# Altium schematic sheet Logic.SchDoc(Logic)
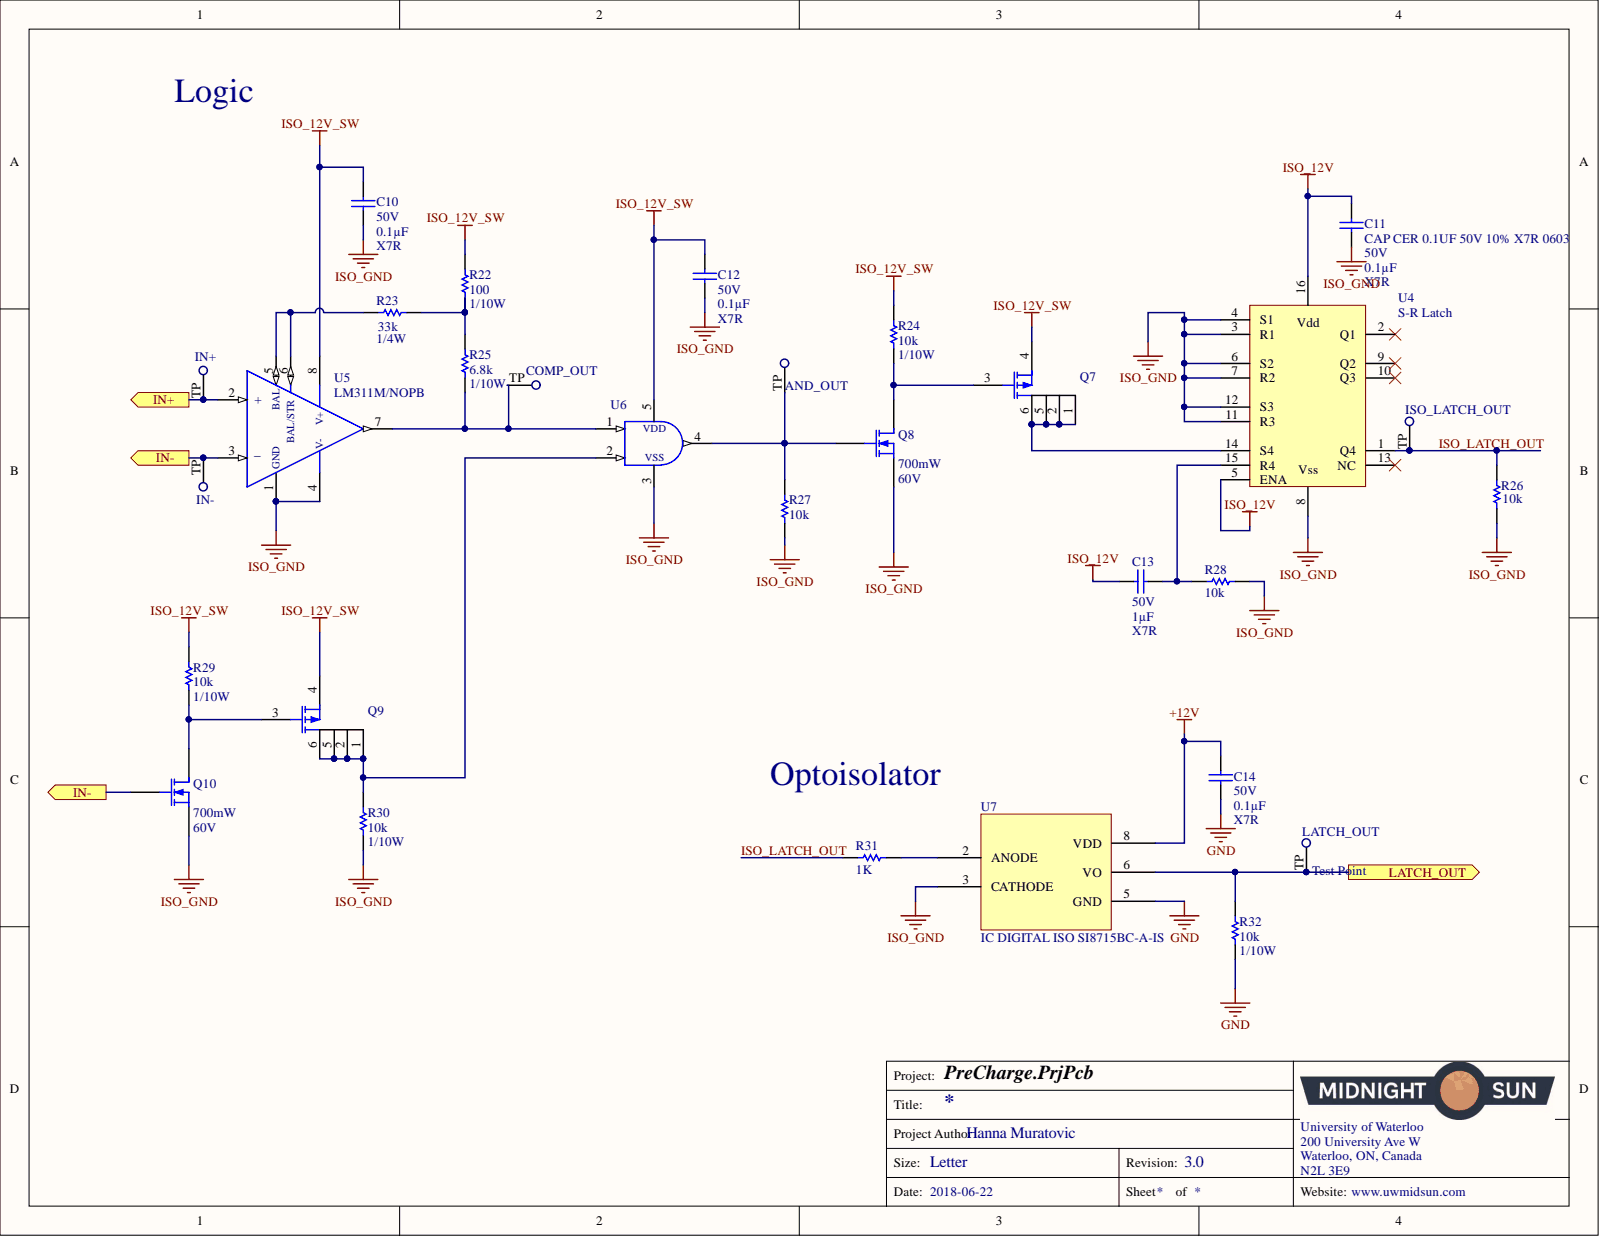
[diagram p0: Logic.SchDoc]

## Parts
AND_OUT  Test Point  [Test Point]  (no BOM)
C10  CAP CER 0.1UF 50V 10% X7R 0603  Voltage: 50V  Temperature Coefficient: X7R  Capacitance: 0.1µF  [CAP, 0603]
C11  CAP CER 0.1UF 50V 10% X7R 0603  Voltage: 50V  [CAP, 0603]
C12  CAP CER 0.1UF 50V 10% X7R 0603  Voltage: 50V  Temperature Coefficient: X7R  Capacitance: 0.1µF  [CAP, 0603]
C13  Voltage: 50V  Capacitance: 1µF  [CAP, 0603]  (hidden comment: CAP CER 1UF 50V 10% X7R 0603)
C14  CAP CER 0.1UF 50V 10% X7R 0603  Voltage: 50V  Temperature Coefficient: X7R  Capacitance: 0.1µF  [CAP, 0603]
COMP_OUT  Test Point  [Test Point]  (no BOM)
IN+  Test Point  [Test Point]  (no BOM)
IN-  Test Point  [Test Point]  (no BOM)
ISO_LATCH_OUT  Test Point  [Test Point]  (no BOM)
LATCH_OUT  Test Point  [Test Point]  (no BOM)
Q7  MOSFET P-CH 30V 4A 1.6W SOT-23-6  [P-MOSFET]  (value not drawn)
Q8  Max Power Dissipation: 700mW  Drain to Source Voltage (Vdss): 60V  [SOT23]  (hidden comment: MOSFET N-CH 60V 310MA SOT23)
Q9  MOSFET P-CH 30V 4A 1.6W SOT-23-6  [P-MOSFET]  (value not drawn)
Q10  Max Power Dissipation: 700mW  Drain to Source Voltage (Vdss): 60V  [SOT23]  (hidden comment: MOSFET N-CH 60V 310MA SOT23)
R22  Power: 1/10W  [RES, 0603]  (hidden comment: RES 100 OHM 1% 1/10W 0603)
R23  Resistance: 33k  [RES, 0603]  (hidden comment: RES 33K OHM 1% 1/4W 0603)
R24  Resistance: 10k  Power: 1/10W  [RES, 0603]  (hidden comment: RES 10K OHM 1% 1/10W 0603)
R25  Resistance: 6.8k  Power: 1/10W  [RES, 0603]  (hidden comment: RES 6.8k OHM 1% 1/10W 0603)
R26  Resistance: 10k  [RES, 0603]  (hidden comment: RES 10K OHM 1% 1/10W 0603)
R27  Resistance: 10k  [RES, 0603]  (hidden comment: RES 10K OHM 1% 1/10W 0603)
R28  Resistance: 10k  [RES, 0603]  (hidden comment: RES 10K OHM 1% 1/10W 0603)
R29  Resistance: 10k  Power: 1/10W  [RES, 0603]  (hidden comment: RES 10K OHM 1% 1/10W 0603)
R30  Resistance: 10k  Power: 1/10W  [RES, 0603]  (hidden comment: RES 10K OHM 1% 1/10W 0603)
R31  1K  [RES, 0603]
R32  Resistance: 10k  Power: 1/10W  [RES, 0603]  (hidden comment: RES 10K OHM 1% 1/10W 0603)
U4  Logic Type: S-R Latch  [IC, SR Latch, CD4043BDR]  (hidden comment: IC SR LATCH 4CH 16SOIC)
U5  Manufacturer Part Number 1: LM311M/NOPB  [SOIC8]  (hidden comment: IC COMPARATOR 8-SOIC)
U6  IC AND GATE 1CH 5-SSOP  [IC, 2-Input AND Gate]  (value not drawn)
U7  IC DIGITAL ISO SI8715BC-A-IS  Manufacturer Part Number: SI8715BC-A-IS  [SOIC8]

## Ports
IN+, IN-, LATCH_OUT

## Nets
+12V: C14.1 U7.8(VDD)
GND: C14.2 R32.2 U7.5(GND)
ISO_12V: C11.2 C13.2 U4.5(ENA) U4.16
ISO_12V_SW: C10.2 C12.2 Q7.4 Q9.4 R22.1 R24.1 R29.1 U5.8 U6.5
ISO_GND: C10.1 C11.1 C12.1 Q8.2 Q10.2 R26.1 R27.1 R28.1 R30.2 U4.3(R1) U4.4(S1) U4.6(S2) U4.7(R2) U4.8 U4.11(R3) U4.12(S3) U5.1(GND) U5.4 U6.3 U7.3(CATHODE)
ISO_LATCH_OUT: ISO_LATCH_OUT.TP R26.2 R31.1 U4.1(Q4)
LATCH_OUT: LATCH_OUT.TP R32.1 U7.6(VO) ; port LATCH_OUT
NetAND_OUT_TP: AND_OUT.TP Q8.3 R27.2 U6.4
NetC13_1: C13.1 R28.2 U4.15(R4)
NetCOMP_OUT_TP: COMP_OUT.TP R25.2 U5.7 U6.1(VDD)
NetIN+_TP: IN+.TP U5.2(+)
NetIN-_TP: IN-.TP Q10.3 U5.3(_)
NetQ7_1: Q7.1 Q7.2 Q7.5 Q7.6 U4.14(S4)
NetQ7_3: Q7.3 Q8.1 R24.2
NetQ9_1: Q9.1 Q9.2 Q9.5 Q9.6 R30.1 U6.2(VSS)
NetQ9_3: Q9.3 Q10.1 R29.2
NetR22_2: R22.2 R23.2 R25.1
NetR23_1: R23.1 U5.5(BAL) U5.6(BAL/STR)
NetR31_2: R31.2 U7.2(ANODE)

Not connected: U4 2(Q1) 9(Q2) 10(Q3) 13(NC)

## Library data of the parts
C10 C12 C14 = CAP CER 0.1UF 50V 10% X7R 0603: 0.10µF ±10% 50V Ceramic Capacitor X7R 0603 (1608 Metric); Manufacturer Part Number 1: 06035C104KAT2A; Manufacturer 1: AVX Corporation; Tolerance: ±10%; Thickness (Max): 0.035" (0.90mm); Supplier Part Number 1: 478-5052-1-ND; Supplier 1: Digi-Key; Size / Dimension: 0.063" L x 0.032" W (1.60mm x 0.81mm); Ratings: -; Part Status: Active; Packaging: Cut Tape (CT); Package / Case: 0603 (1608 Metric); Operating Temperature: -55°C ~ 125°C; Mounting Type: Surface Mount, MLCC; Lead Style: -; Lead Spacing: -; Height - Seated (Max): -; ComponentLink1URL: http://datasheets.avx.com/X7RDielectric.pdf; ComponentLink1Description: http://datasheets.avx.com/X7RDielectric.pdf; Component Kind: Standard; Library Name: Schematic Diagrams.SchLib
C11 = CAP CER 0.1UF 50V 10% X7R 0603: 0.10µF ±10% 50V Ceramic Capacitor X7R 0603 (1608 Metric); Manufacturer Part Number 1: 06035C104KAT2A; Manufacturer 1: AVX Corporation; Capacitance: 0.1µF; Tolerance: ±10%; Thickness (Max): 0.035" (0.90mm); Temperature Coefficient: X7R; Supplier Part Number 1: 478-5052-1-ND; Supplier 1: Digi-Key; Size / Dimension: 0.063" L x 0.032" W (1.60mm x 0.81mm); Ratings: -; Part Status: Active; Packaging: Cut Tape (CT); Package / Case: 0603 (1608 Metric); Operating Temperature: -55°C ~ 125°C; Mounting Type: Surface Mount, MLCC; Lead Style: -; Lead Spacing: -; Height - Seated (Max): -; ComponentLink1URL: http://datasheets.avx.com/X7RDielectric.pdf; ComponentLink1Description: http://datasheets.avx.com/X7RDielectric.pdf; Component Kind: Standard; Library Name: Schematic Diagrams.SchLib
C13 = CAP CER 1UF 50V 10% X7R 0603: 1µF ±10% 50V Ceramic Capacitor X7R 0603 (1608 Metric); Manufacturer Part Number 1: UMK107AB7105KA-T; Manufacturer 1: Taiyo Yuden; Tolerance: ±10%; Thickness (Max): 0.037" (0.95mm); Temperature Coefficient: X7R; Supplier Part Number 1: 587-3247-1-ND; Supplier 1: Digi-Key; Size / Dimension: 0.063" L x 0.031" W (1.60mm x 0.80mm); Ratings: -; Part Status: Active; Packaging: Cut Tape (CT); Package / Case: 0603 (1608 Metric); Operating Temperature: -55°C ~ 125°C; Mounting Type: Surface Mount, MLCC; Lead Style: -; Lead Spacing: -; Height - Seated (Max): -; ComponentLink1URL: http://www.yuden.co.jp/productdata/catalog/en/mlcc_all_e.pdf; ComponentLink1Description: http://www.yuden.co.jp/productdata/catalog/en/mlcc_all_e.pdf; Component Kind: Standard; Library Name: Schematic Diagrams.SchLib
Q7 Q9 = MOSFET P-CH 30V 4A 1.6W SOT-23-6: P-Channel 30V 4A (Ta) 1.6W (Ta) Surface Mount SOT-23-6; Manufacturer Part Number 1: STT4P3LLH6; Manufacturer 1: STMicroelectronics; Vgs(th) (Max) @ Id: 2.5V @ 250µA; Vgs (Max): ±20V; Rds On (Max) @ Id, Vgs: 56 mOhm @ 2A, 10V; Input Capacitance (Ciss) (Max) @ Vds: 639pF @ 25V; Gate Charge (Qg) (Max) @ Vgs: 6nC @ 4.5V; Drive Voltage (Max Rds On,  Min Rds On): 4.5V, 10V; Current - Continuous Drain (Id) @ 25°C: 4A (Ta); Technology: MOSFET (Metal Oxide); Supplier Part Number 1: 497-15521-1-ND; Supplier Device Package: SOT-23-6; Supplier 1: Digi-Key; Simulation: PMOS; Power Dissipation (Max): 1.6W (Ta); Part Status: Active; Packaging: Cut Tape (CT); Package / Case: SOT-23-6; Operating Temperature: 150°C (TJ); Mounting Type: Surface Mount; FET Type: P-Channel; FET Feature: -; Drain to Source Voltage (Vdss): 30V; ComponentLink1URL: http://www.st.com/content/ccc/resource/technical/document/datasheet/13/e4/a1/65/8b/79/44/73/DM00084060.pdf/files/DM00084060.pdf/jcr:content/translations/en.DM00084060.pdf; ComponentLink1Description: http://www.st.com/content/ccc/resource/technical/document/datasheet/13/e4/a1/65/8b/79/44/73/DM00084060.pdf/files/DM00084060.pdf/jcr:content/translations/en.DM00084060.pdf; Component Kind: Standard; Library Name: Schematic Diagrams.SchLib
Q8 Q10 = MOSFET N-CH 60V 310MA SOT23: N-Channel 60V 310mA (Ta) 370mW (Ta) Surface Mount SOT-23; Manufacturer Part Number: DMN6140L-7; Manufacturer: Diodes; Turn-On Delay Time: 2.6ns; Turn-Off Delay Time: 16.3ns; Threshold Voltage: 3V; Rise Time: 3.6ns; Rds On Max: 140mR; Power Dissipation: 540mW; Number of Elements: 1; Input Capacitance: 315pF; Gate to Source Voltage (Vgs): 20V; FET Type: 1 N-Channel; Fall Time: 2.7ns; Element Configuration: Single; Continuous Drain Current (ID): 1.6A; Width: 1.4mm; Weight: 0.000282oz; Supplier Part Number 1: DMN65D8L-7DICT-ND; Supplier 1: Digi-Key; Stock 1: 0; REACH SVHC: No SVHC; Packaging: Tape and Reel; Package Quantity: 3000; Number of Pins: 3; Number of Channels: 1; Mount: Surface Mount; Min Operating Temperature: -55°C; Max Operating Temperature: 150°C; Length: 3mm; Lead Free: Lead Free; Height: 1.1mm; Drain to Source Resistance: 140mR; Drain to Source Breakdown Voltage: 60V; ComponentLink6URL: https://datasheet.ciiva.com/6371/dmn65d8l-6371128.pdf; ComponentLink6Description: https://datasheet.ciiva.com/6371/dmn65d8l-6371128.pdf; ComponentLink5URL: https://datasheet.ciiva.com/13058/dmn65d8l-219098-13058455.pdf; ComponentLink5Description: https://datasheet.ciiva.com/13058/dmn65d8l-219098-13058455.pdf; ComponentLink4URL: https://datasheet.ciiva.com/6371/dmn65d8l-6371126.pdf; ComponentLink4Description: https://datasheet.ciiva.com/6371/dmn65d8l-6371126.pdf; ComponentLink3URL: https://datasheet.ciiva.com/6371/dmn65d8l-6371124.pdf; ComponentLink3Description: https://datasheet.ciiva.com/6371/dmn65d8l-6371124.pdf; ComponentLink2URL: https://datasheet.ciiva.com/29747/dmn6140l-29747660.pdf; ComponentLink2Description: https://datasheet.ciiva.com/29747/dmn6140l-29747660.pdf; ComponentLink1URL: https://datasheet.ciiva.com/29747/dmn6140l-29747654.pdf; ComponentLink1Description: https://datasheet.ciiva.com/29747/dmn6140l-29747654.pdf; Component Kind: Standard; Case/Package: TO-236-3; Library Name: Schematic Diagrams.SchLib
R22 = RES 100 OHM 1% 1/10W 0603: 100 Ohm ±1% 0.1W, 1/10W Chip Resistor 0603 (1608 Metric) Moisture Resistant Thick Film; Manufacturer Part Number 1: RC0603FR-07100RL; Manufacturer 1: Yageo; Temperature Coefficient: ±100ppm/°C; Resistance: 100; Tolerance: ±1%; Supplier Part Number 1: 311-100HRCT-ND; Supplier Device Package: 0603; Supplier 1: Digi-Key; Size / Dimension: 0.063" L x 0.031" W (1.60mm x 0.80mm); Part Status: Active; Packaging: Cut Tape (CT); Package / Case: 0603 (1608 Metric); Operating Temperature: -55°C ~ 155°C; Height - Seated (Max): 0.022" (0.55mm); Failure Rate: -; Composition: Thick Film; ComponentLink1URL: http://www.yageo.com/documents/recent/PYu-RC_Group_51_RoHS_L_7.pdf; ComponentLink1Description: http://www.yageo.com/documents/recent/PYu-RC_Group_51_RoHS_L_7.pdf; Component Kind: Standard; Library Name: Schematic Diagrams.SchLib
R23 = RES 33K OHM 1% 1/4W 0603: RES SMD 33K OHM 1% 1/4W 0603; Manufacturer Part Number 1: ERJ-PA3F3302V; Manufacturer 1: Panasonic Electronic Components; Temperature Coefficient: ±100ppm/°C; Tolerance: ±1%; Supplier Part Number 1: P33KBYCT-ND; Supplier Device Package: 0603; Supplier 1: Digi-Key; Size / Dimension: 0.063" L x 0.031" W (1.60mm x 0.80mm); Power: 1/4W; Part Status: Active; Packaging: Cut Tape (CT); Package / Case: 0603 (1608 Metric); Operating Temperature: -55°C ~ 155°C; Height - Seated (Max): 0.022" (0.55mm); Failure Rate: -; Composition: Thick Film; ComponentLink1URL: https://industrial.panasonic.com/cdbs/www-data/pdf/RDO0000/AOA0000C291.pdf; ComponentLink1Description: https://industrial.panasonic.com/cdbs/www-data/pdf/RDO0000/AOA0000C291.pdf; Component Kind: Standard; Library Name: Schematic Diagrams.SchLib
R24 R26-R30 R32 = RES 10K OHM 1% 1/10W 0603: RES SMD 10K OHM 1% 1/10W 0603; Manufacturer Part Number 1: RC0603FR-0710KL; Manufacturer 1: Yageo; Temperature Coefficient: ±100ppm/°C; Tolerance: ±1%; Supplier Part Number 1: 311-10.0KHRCT-ND; Supplier Device Package: 0603; Supplier 1: Digi-Key; Size / Dimension: 0.063" L x 0.031" W (1.60mm x 0.80mm); Part Status: Active; Packaging: Cut Tape (CT); Package / Case: 0603 (1608 Metric); Operating Temperature: -55°C ~ 155°C; Height - Seated (Max): 0.022" (0.55mm); Failure Rate: -; Composition: Thick Film; ComponentLink1URL: http://www.yageo.com/documents/recent/PYu-RC_Group_51_RoHS_L_7.pdf; ComponentLink1Description: http://www.yageo.com/documents/recent/PYu-RC_Group_51_RoHS_L_7.pdf; Component Kind: Standard; Library Name: Schematic Diagrams.SchLib
  R26-R28: Power: 1/10W
R25 = RES 6.8k OHM 1% 1/10W 0603: RES SMD 6.8K OHM 1% 1/10W 0603; Manufacturer Part Number 1: RMCF0603FT6K80; Manufacturer 1: Stackpole Electronics Inc.; Temperature Coefficient: ±100ppm/°C; Tolerance: ±1%; Supplier Part Number 1: RMCF0603FT6K80CT-ND; Supplier Device Package: 0603; Supplier 1: Digi-Key; Size / Dimension: 0.061" L x 0.031" W (1.55mm x 0.80mm); Part Status: Active; Packaging: Cut Tape (CT); Package / Case: 0603 (1608 Metric); Operating Temperature: -55°C ~ 155°C; Height - Seated (Max): 0.022" (0.55mm); Failure Rate: -; Composition: Thick Film; ComponentLink1URL: https://www.seielect.com/Catalog/SEI-RMCF_RMCP.pdf; ComponentLink1Description: https://www.seielect.com/Catalog/SEI-RMCF_RMCP.pdf; Component Kind: Standard; Library Name: Schematic Diagrams.SchLib
R31 = RES 1K OHM 5% 1/10W 0603: 1k Ohm ±5% 0.1W, 1/10W Chip Resistor 0603 (1608 Metric); Manufacturer Part Number: RC0603JR-071KL; Manufacturer: Yageo; Temperature Coefficient: ±100ppm/°C; Tolerance: ±5%; Supplier Part Number 1: 311-1.0KGRCT-ND; Supplier Device Package: 0603; Supplier 1: Digi-Key; Stock 1: 4415240; Size / Dimension: 0.063" L x 0.031" W (1.60mm x 0.80mm); RoHS: RoHS Compliant; Resistance (Ohms): 1k; Pricing 1: 1=0.15, 10=0.017, 100=0.0071, 1000=0.0032, 2500=0.00278 (CAD); Power (Watts): 0.1W, 1/10W; Part Status: Active; Packaging: Cut Tape (CT); Package / Case: 0603 (1608 Metric); Operating Temperature: -55°C ~ 155°C; Height - Seated (Max): 0.022" (0.55mm); Features: Moisture Resistant; Failure Rate: -; Composition: Thick Film; ComponentLink1URL: http://www.yageo.com/documents/recent/PYu-RC_Group_51_RoHS_L_7.pdf; ComponentLink1Description: http://www.yageo.com/documents/recent/PYu-RC_Group_51_RoHS_L_7.pdf; Component Kind: Standard; Category: Resistors; Library Name: Schematic Diagrams.SchLib
U4 = IC SR LATCH 4CH 16SOIC: S-R Latch 4 Channel 1:1 IC Tri-State 16-TSSOP; Manufacturer Part Number 1: CD4043BDR; Manufacturer 1: Texas Instruments; Voltage - Supply: 3 V ~ 18 V; Delay Time - Propagation: 50ns; Current - Output High, Low: 6.8mA, 6.8mA; Supplier Part Number 1: 296-31496-1-ND; Supplier Device Package: 16-SOIC; Supplier 1: Digi-Key; Part Status: Active; Packaging: Cut Tape (CT); Package / Case: 16-SOIC (0.154", 3.90mm Width); Output Type: Tri-State; Operating Temperature: -55°C ~ 125°C; Mounting Type: Surface Mount; ComponentLink1URL: http://www.ti.com/lit/ds/symlink/cd4043b.pdf; ComponentLink1Description: http://www.ti.com/lit/ds/symlink/cd4043b.pdf; Component Kind: Standard; Circuit: 1:1; Library Name: Schematic Diagrams.SchLib
U5 = IC COMPARATOR 8-SOIC: Comparator General Purpose DTL, MOS, Open-Collector, Open-Emitter, RTL, TTL 8-SOIC; Manufacturer 1: Texas Instruments; Voltage - Supply, Single/Dual (±): 5 V ~ 36 V, ±2.5 V ~ 18 V; Voltage - Input Offset (Max): 7.5mV @ ±15V; Current - Quiescent (Max): 7.5mA; Current - Input Bias (Max): 0.25µA @ ±15V; Type: General Purpose; Supplier Part Number 1: LM311MNS/NOPB-ND; Supplier Device Package: 8-SOIC; Supplier 1: Digi-Key; Propagation Delay (Max): -; Part Status: Active; Packaging: Tube; Package / Case: 8-SOIC (0.154", 3.90mm Width); Output Type: DTL, MOS, Open-Collector, Open-Emitter, RTL, TTL; Operating Temperature: 0°C ~ 70°C; Mounting Type: Surface Mount; Hysteresis: -; Current - Output (Typ): -; ComponentLink1URL: http://www.ti.com/lit/ds/symlink/lm311-n.pdf; ComponentLink1Description: http://www.ti.com/lit/ds/symlink/lm311-n.pdf; Component Kind: Standard; CMRR, PSRR (Typ): -; Library Name: Schematic Diagrams.SchLib
U6 = IC AND GATE 1CH 5-SSOP: AND Gate IC 1 Channel 5-SSOP; Manufacturer Part Number 1: BU4S81G2-TR; Manufacturer 1: Rohm Semiconductor; Voltage - Supply: 3 V ~ 16 V; Number of Inputs: 2; Number of Circuits: 1; Max Propagation Delay @ V, Max CL: 30ns @ 15V, 50pF; Logic Level - Low: 1.5 V ~ 4 V; Logic Level - High: 3.5 V ~ 11 V; Current - Quiescent (Max): 1µA; Current - Output High, Low: 3.4mA, 3.4mA; Supplier Part Number 1: BU4S81G2CT-ND; Supplier Device Package: 5-SSOP; Supplier 1: Digi-Key; Part Status: Active; Packaging: Cut Tape (CT); Package / Case: SC-74A, SOT-753; Operating Temperature: -40°C ~ 85°C; Mounting Type: Surface Mount; Logic Type: AND Gate; ComponentLink1URL: http://rohmfs.rohm.com/en/products/databook/datasheet/ic/logic_switch/standard_logic/bu4s81g2-e.pdf; ComponentLink1Description: http://rohmfs.rohm.com/en/products/databook/datasheet/ic/logic_switch/standard_logic/bu4s81g2-e.pdf; Component Kind: Standard; Library Name: Schematic Diagrams.SchLib
U7 = IC DIGITAL ISO SI8715BC-A-IS: General Purpose Digital Isolator 3750Vrms 1 Channel 15Mbps 35kV/µs CMTI 8-SOIC (0.154", 3.90mm Width); Manufacturer: Silicon Labs; Voltage - Supply: 2.5 V ~ 5.5 V; Rise / Fall Time (Typ): 2.5ns, 2.5ns; Pulse Width Distortion (Max): 25ns; Propagation Delay tpLH / tpHL (Max): 50ns, 50ns; Isolated Power: No; Inputs - Side 1/Side 2: 1/0; Channel Type: Unidirectional; Voltage - Isolation: 3750Vrms; Type: General Purpose; Technology: Capacitive Coupling; Supplier Part Number 1: 336-3027-ND; Supplier Device Package: 8-SOIC; Supplier 1: Digi-Key; Stock 1: 497; RoHS: RoHS Compliant; Pricing 1: 1=1.45, 25=1.4016, 100=1.3478, 1000=1.33134 (CAD); Part Status: Active; Packaging: Tube; Package / Case: 8-SOIC (0.154", 3.90mm Width); Operating Temperature: -40°C ~ 125°C; Data Rate: 15Mbps; ComponentLink1URL: http://www.silabs.com/documents/public/data-sheets/Si871x-2x.pdf; ComponentLink1Description: http://www.silabs.com/documents/public/data-sheets/Si871x-2x.pdf; Component Kind: Standard; Common Mode Transient Immunity (Min): 35kV/µs; Category: Isolators; Library Name: Schematic Diagrams.SchLib
AND_OUT COMP_OUT IN+ IN- ISO_LATCH_OUT LATCH_OUT = Test Point: Library Name: Schematic Diagrams.SchLib
